# Altium schematic sheet MCU.SchDoc(MCU)
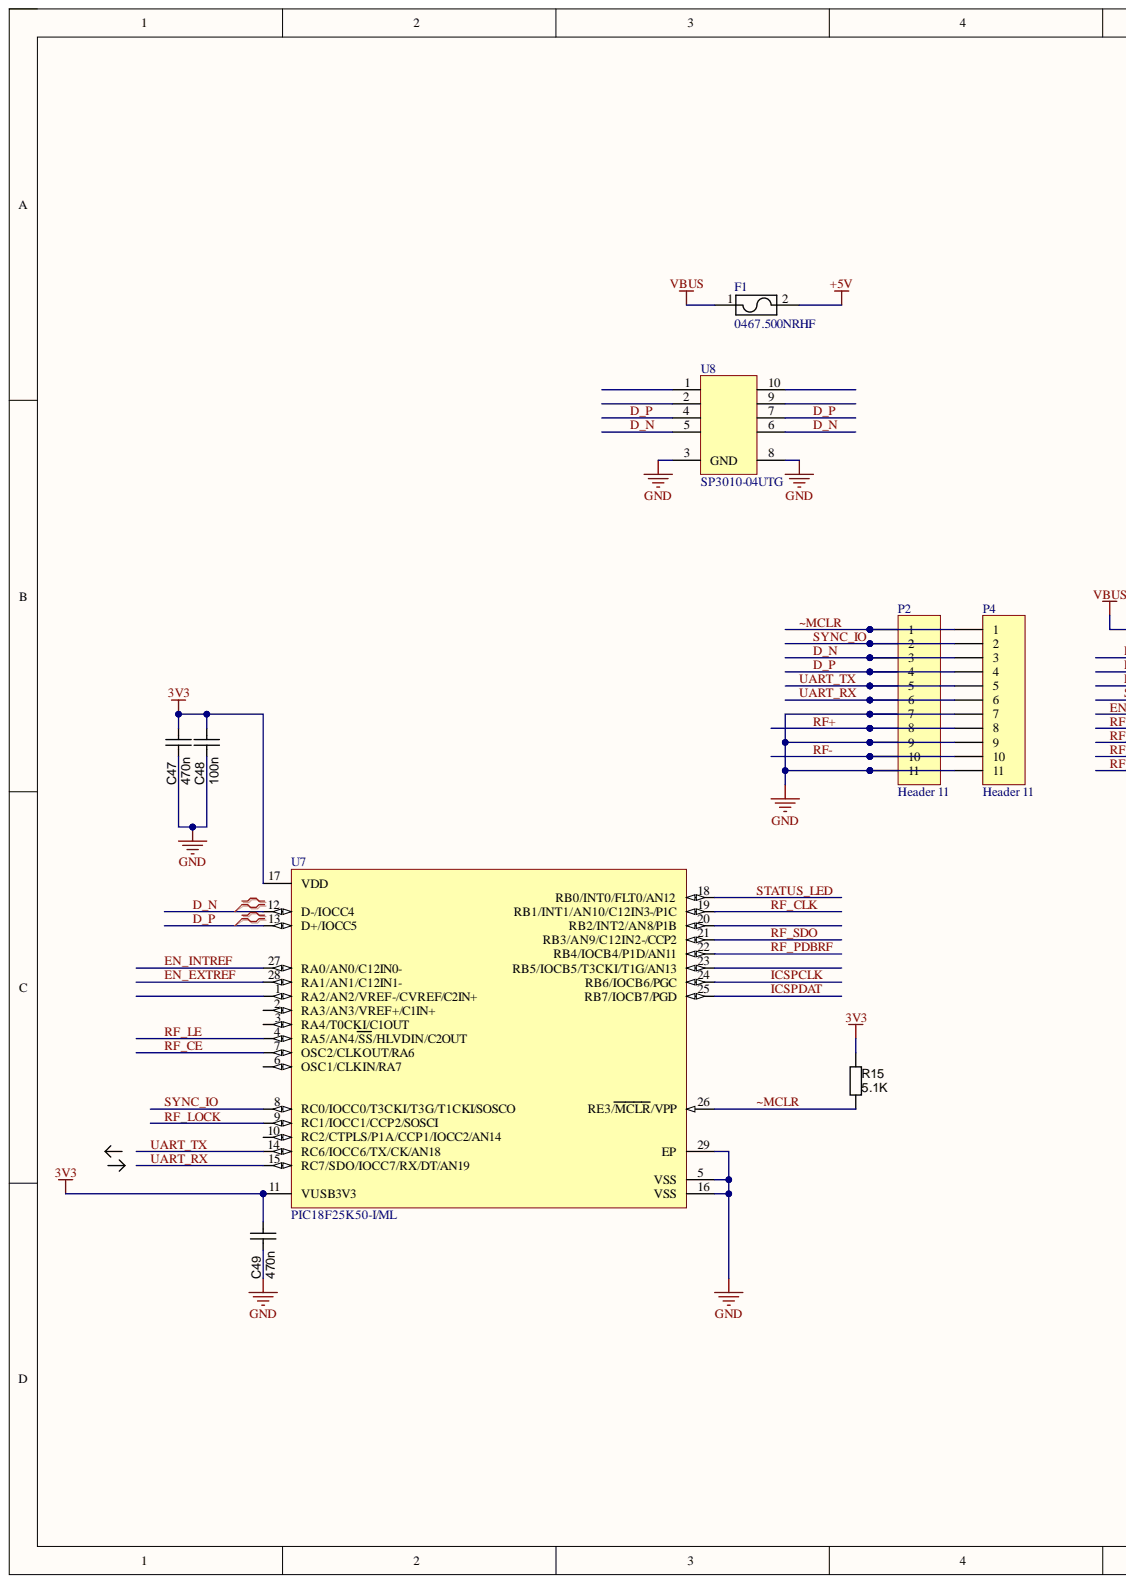
[diagram p0: MCU.SchDoc, left]
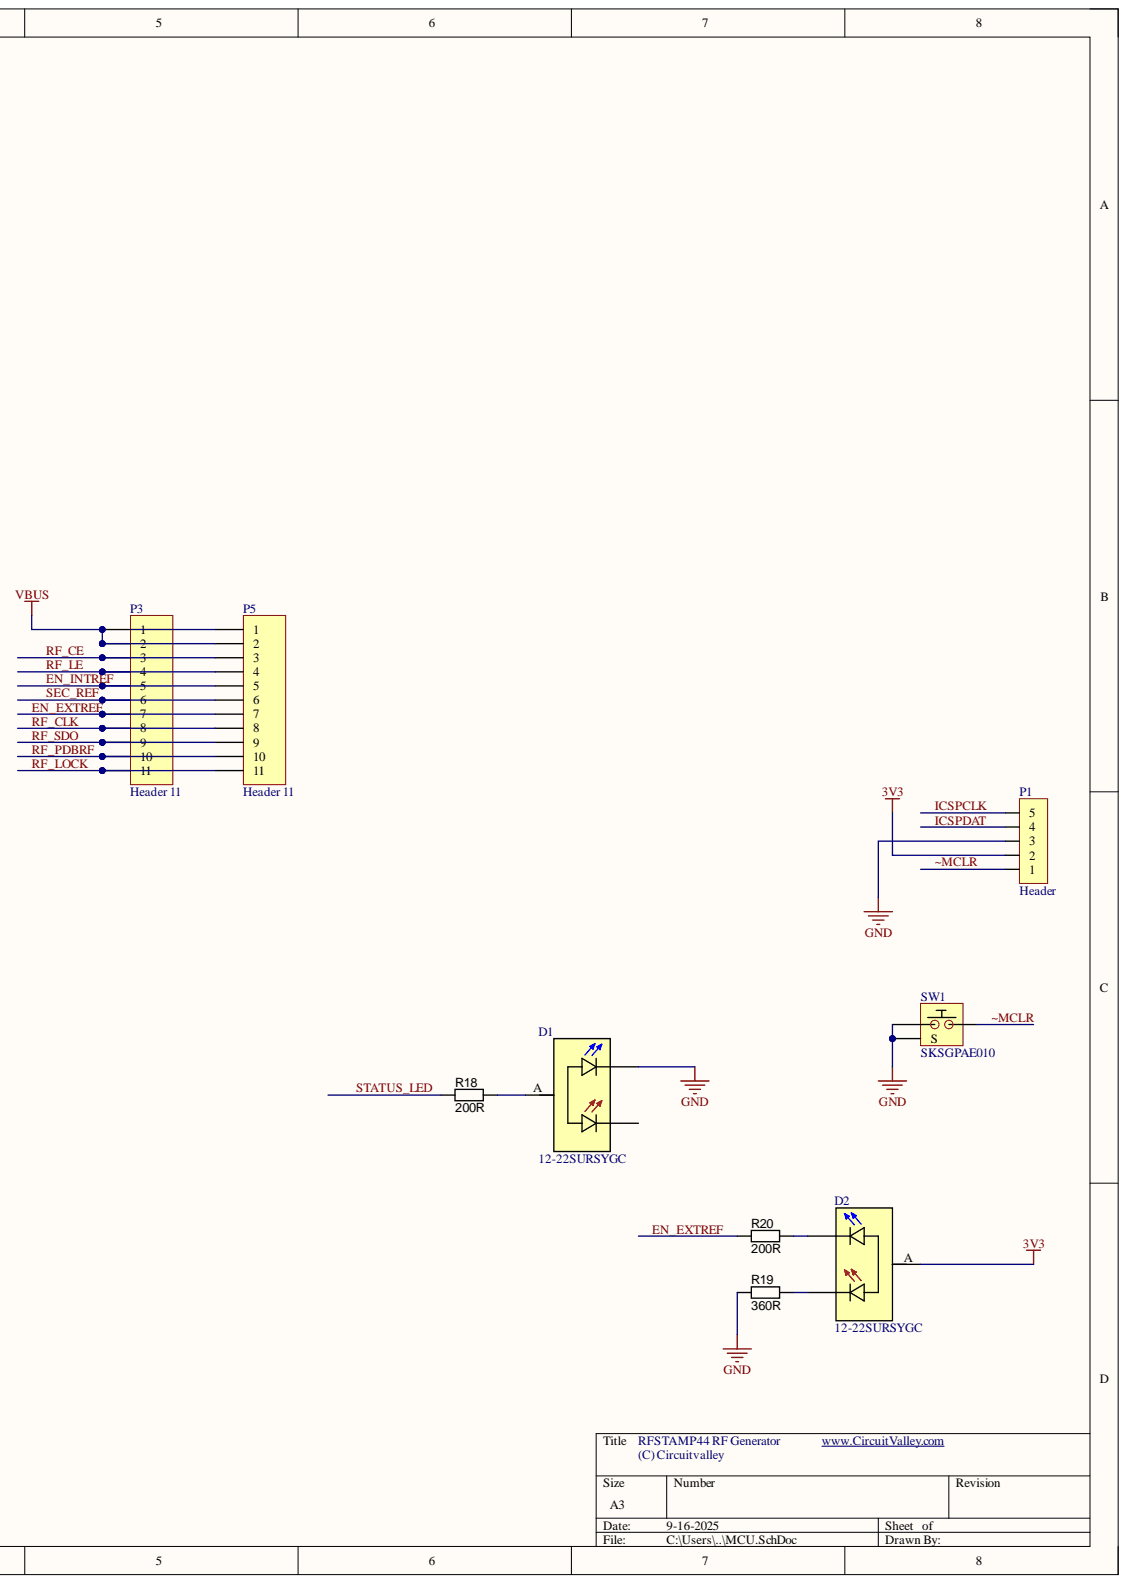
[diagram p1: MCU.SchDoc, right]

## Parts
C47  470n  [CAPC1005N]
C48  100n  [CAPC1005N]
C49  470n  [CAPC1005N]
D1  12-22SURSYGC  [12-22SURSYGC/S530-A2/TR8]
D2  12-22SURSYGC  [12-22SURSYGC/S530-A2/TR8]
F1  0467.500NRHF  [0467005.NRHF]
P1  Header  [HEADER5X]
P2  Header 11  [HDR1X11]
P3  Header 11  [HDR1X11]
P4  Header 11  [HDR1X11]
P5  Header 11  [HDR1X11]
R15  value 5.1K  [RESC1005N]  (hidden comment: Thick Film)
R18  value 200R  [RESC1005N]  (hidden comment: Thick Film)
R19  value 360R  [RESC1005N]  (hidden comment: Thick Film)
R20  value 200R  [RESC1005N]  (hidden comment: Thick Film)
SW1  SKSGPAE010  [SKSGPAE010]
U7  PIC18F25K50-I/ML  [QFN28]
U8  SP3010-04UTG  [uDFN-10]

## Nets
3V3: C47.2 C48.2 C49.2 D2.A P1.2 R15.2 U7.11(VUSB3V3) U7.17(VDD)
+5V: F1.2
GND: C47.1 C48.1 C49.1 D1.C1 P1.3 P2.7 P2.9 P2.11 P4.7 P4.9 P4.11 R19.2 SW1.1 SW1.3 U7.5(VSS) U7.16(VSS) U7.29(EP) U8.3(GND) U8.8
VBUS: F1.1 P3.1 P3.2 P5.2
D_N: P2.3 P4.3 U7.12(D-/IOCC4) U8.5 U8.6
D_P: P2.4 P4.4 U7.13(D+/IOCC5) U8.4 U8.7
EN_EXTREF: P3.7 P5.7 R20.2 U7.28(RA1/AN1/C12IN1-)
EN_INTREF: P3.5 P5.5 U7.27(RA0/AN0/C12IN0-)
ICSPCLK: P1.5 U7.24(RB6/IOCB6/PGC)
ICSPDAT: P1.4 U7.25(RB7/IOCB7/PGD)
RF+: P2.8 P4.8
RF-: P2.10 P4.10
RF_CE: P3.3 P5.3 U7.7(OSC2/CLKOUT/RA6)
RF_CLK: P3.8 P5.8 U7.19(RB1/INT1/AN10/C12IN3-/P1C)
RF_LE: P3.4 P5.4 U7.4(RA5/AN4/SS/HLVDIN/C2OUT)
RF_LOCK: P3.11 P5.11 U7.9(RC1/IOCC1/CCP2/SOSCI)
RF_PDBRF: P3.10 P5.10 U7.22(RB4/IOCB4/P1D/AN11)
RF_SDO: P3.9 P5.9 U7.21(RB3/AN9/C12IN2-/CCP2)
SEC_REF: P3.6 P5.6
STATUS_LED: R18.1 U7.18(RB0/INT0/FLT0/AN12)
SYNC_IO: P2.2 P4.2 U7.8(RC0/IOCC0/T3CKI/T3G/T1CKI/SOSCO)
UART_RX: P2.6 P4.6 U7.15(RC7/SDO/IOCC7/RX/DT/AN19)
UART_TX: P2.5 P4.5 U7.14(RC6/IOCC6/TX/CK/AN18)
~MCLR: P1.1 P2.1 P4.1 R15.1 SW1.2 U7.26(RE3/MCLR/VPP)
NetD1_A: D1.A R18.2
NetD2_C1: D2.C1 R20.1
NetD2_C2: D2.C2 R19.1

Not connected: D1 C2; P5 1; U7 1(RA2/AN2/VREF-/CVREF/C2IN+) 2(RA3/AN3/VREF+/C1IN+) 3(RA4/T0CKI/C1OUT) 6(OSC1/CLKIN/RA7) 10(RC2/CTPLS/P1A/CCP1/IOCC2/AN14) 20(RB2/INT2/AN8/P1B) 23(RB5/IOCB5/T3CKI/T1G/AN13); U8 1 2 9 10

## Library data of the parts
C47-C49 = CAPC_10n_0402_16V_10%: Capacitor SMD Cer 10nF 10% 16V X7R 0402; Manufacturer 1: AVX Corporation; Manufacturer Part Number 1: 0402YC103KAT2A; Ratings: 16V; X7R; Component Kind: Standard; ComponentLink1Description: http://www.avx.com/docs/Catalogs/cx7r.pdf; ComponentLink1URL: http://www.avx.com/docs/Catalogs/cx7r.pdf; ComponentLink2Description: http://www.yageo.com/documents/recent/UPY-GPHC_X7R_6.3V-to-50V_8.pdf; ComponentLink2URL: http://www.yageo.com/documents/recent/UPY-GPHC_X7R_6.3V-to-50V_8.pdf; Library Name: PBL - Capacitors.SCHLIB; Manufacturer 2: Yageo; Manufacturer Part Number 2: CC0402KRX7R7BB103; Operating Temperature: -55°C ~ 125°C; Package / Case: 0402 (1005 Metric); Size / Dimension: 0.039" L x 0.020" W (1.00mm x 0.50mm); Supplier 1: Digi-Key; Supplier 2: Digi-Key; Supplier Part Number 1: 478-1114-1-ND; Supplier Part Number 2: 311-1042-1-ND; Tolerance: ±10%
  C47 C49: Value: 470n
  C48: Value: 100n
D1 D2 = BI_LED: 12-22SURSYGC/S530-A3/E2/TR8; Component Kind: Standard; Library Name: cvlib.SchLib
F1 = Fuse: 0467005.NRHF; Component Kind: Standard; Library Name: cvlib.SchLib
P1 = Header_5Pin: 5 Pin Header; Component Kind: Standard; Library Name: cvlib.SchLib
P2-P5 = Header 11: Header, 11-Pin; Component Kind: Standard; LatestRevisionDate: 17-Jul-2002; LatestRevisionNote: Re-released for DXP Platform.; Library Name: Miscellaneous Connectors.IntLib; Signal Integrity: Connector; Publisher: Altium Limited
R15 R18-R20 = RES_3K3_0402_5%: Resistor SMD chip 3.3k Ohm 0.063W 5% 0402; Manufacturer 1: Yageo; Manufacturer Part Number 1: RC0402JR-073K3L; Ratings: 1/16 W; Component Kind: Standard; ComponentLink1Description: http://www.yageo.com/documents/recent/PYu-RC0402_51_RoHS_L_6.pdf; ComponentLink1URL: http://www.yageo.com/documents/recent/PYu-RC0402_51_RoHS_L_6.pdf; Library Name: PBL - Resistors.SCHLIB; Material: Thick Film; Package / Case: 0402 (1005 metric); Supplier 1: Digi-Key; Supplier Part Number 1: 311-3.3KJRCT-ND; Tolerance: ±5%
SW1 = PushButton_Grounded: Component Kind: Standard; Library Name: cvlib.SchLib
U7 = PIC18F25K50-I/ML: Low-Power, High-Performance Microcontroller with XLP Technology, 48 MHz, 24 I/O, -40 to 85 degC, 28-pin QFN (ML28), Tube; Manufacturer: Microchip; # of A/D Channels: 14; Digital Communication: 1 -A/E/USART, 1 -MSSP(SPI/I2C); EEPROM Data Memory (bytes): 256; I/O Pins: 24; Internal Oscillator: 16 MHz, 31 kHz; Max. CPU Speed MHz: 48; Memory Type: Flash; Operation Voltage Range: 1.8V - 5.5V; Program Memory KBytes: 32; Program Memory KWords: 16; RAM: 2048; Timers: 2 - 8-bit, 2 - 16-bit; Component Kind: Standard; ComponentLink1Description: Datasheet; ComponentLink1URL: http://ww1.microchip.com/downloads/en/DeviceDoc/30684A.pdf; ComponentLink2Description: Package Specification; ComponentLink2URL: http://www.microchip.com/stellent/groups/techpub_sg/documents/packagingspec/en012702.pdf; ComponentLink3Description: URL Link1; ComponentLink3URL: Product URL:http://www.microchip.com/datasheet/PIC18F25K50; DatasheetVersion: Rev. A; Mounting Technology: Surface Mount; PackageDescription: 28-Lead Plastic Quad Flat, 6 x 6 mm Body, 0.65 mm Pitch; PackageReference: QFN-ML28; PackageVersion: C04-105C, 12/2012; Packing: TUBE; PartNumber: PIC18F25K50-I/ML; RoHS: TRUE; Supplier 1: Digi-Key; Supplier 2: Farnell; Supplier 3: Newark; Supplier 4: Mouser; Supplier Part Number 1: PIC18F25K50-I/ML-ND; Supplier Part Number 2: 2305830; Supplier Part Number 3: 06W2971; Supplier Part Number 4: 579-PIC18F25K50-I/ML; Library Name: cvlib.SchLib
U8 = SP3010-04UTG: ESD Protection; Component Kind: Standard; Library Name: cvlib.SchLib
